# Altium schematic sheet ARM_JTAG.SchDoc(ARM_JTAG)
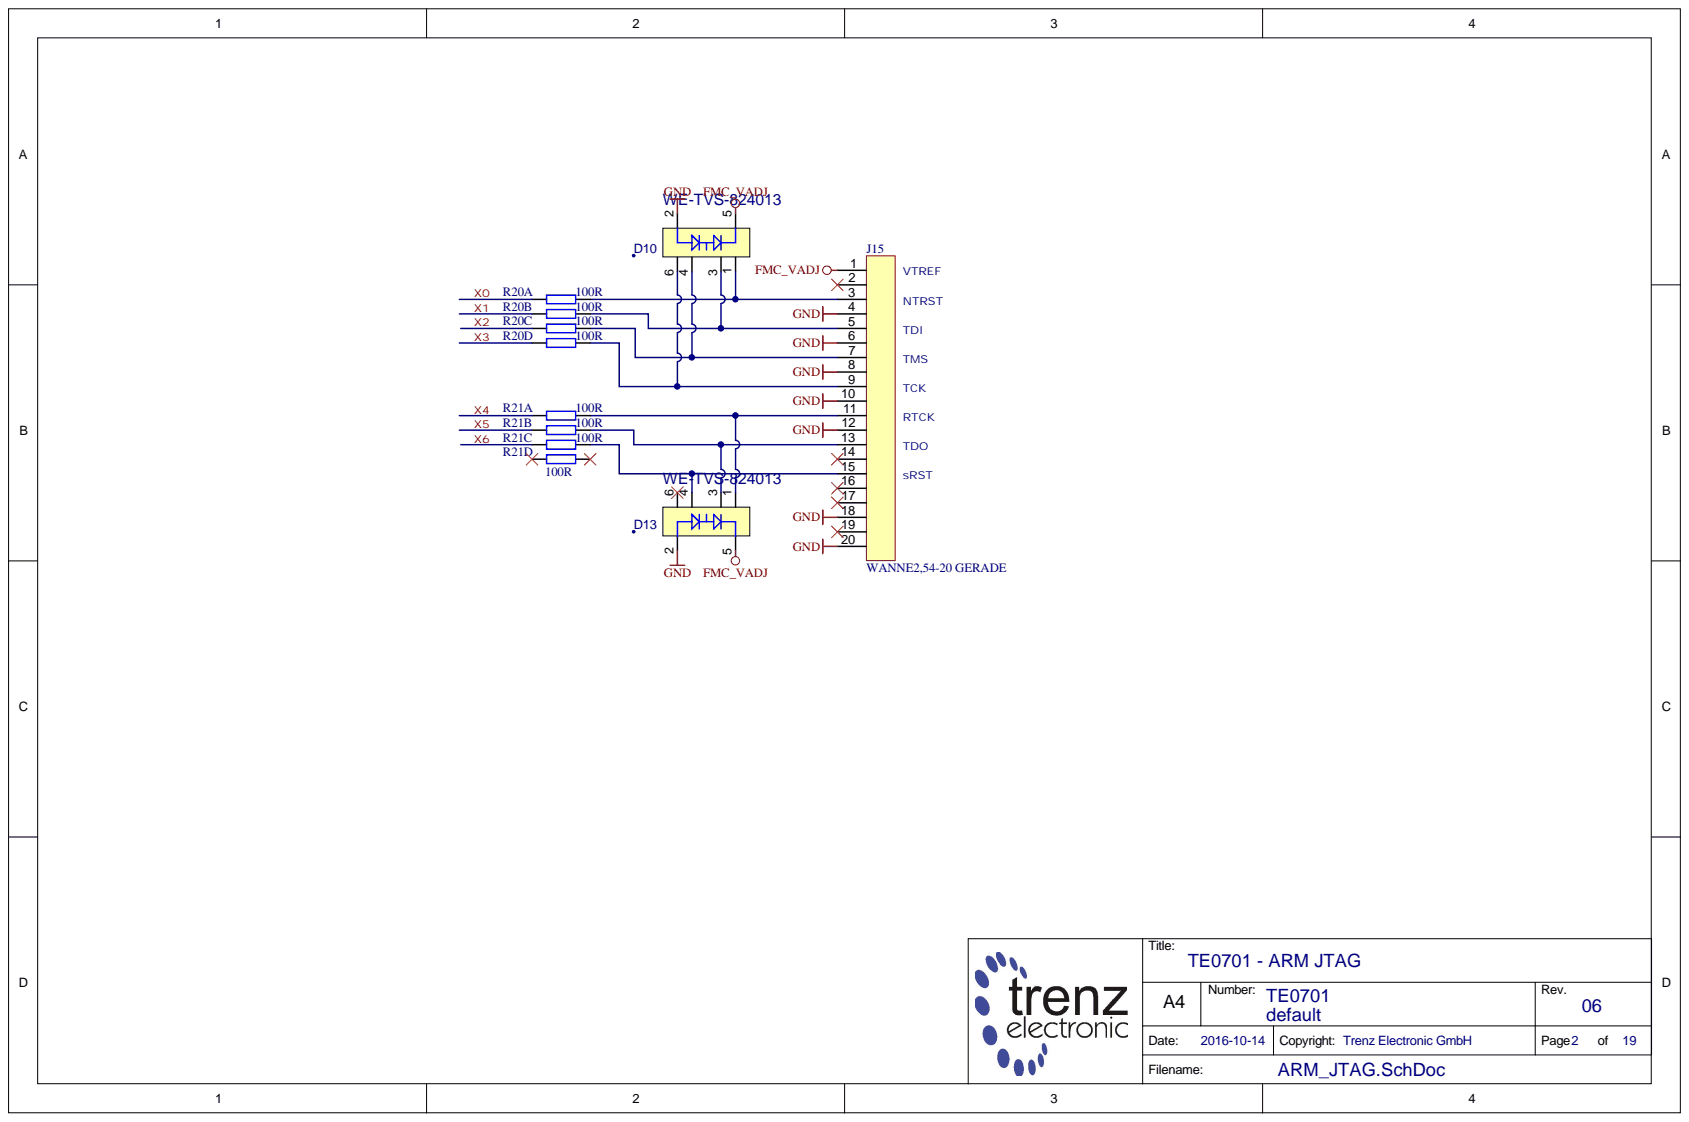
[diagram p0: ARM_JTAG.SchDoc]

## Parts
D10  WE-TVS-824013  [SOT95P280X145-6N]
D13  WE-TVS-824013  [SOT95P280X145-6N]
J15  WANNE2,54-20 GERADE  [WANNE2,54-20 GERADE]
R20  100R  [RESCAV80P160X320X70-8N]  (parts A,B,C,D)
R21  100R  [RESCAV80P160X320X70-8N]  (parts A,B,C,D)

## Nets
FMC_VADJ: D10.5 D13.5 J15.1
GND: D10.2 D13.2 J15.4 J15.6 J15.8 J15.10 J15.12 J15.18 J15.20
X0: R20.8
X1: R20.7
X2: R20.6
X3: R20.5
X4: R21.8
X5: R21.7
X6: R21.6
NetD10_1: D10.1 J15.3 R20.1
NetD10_3: D10.3 J15.5 R20.2
NetD10_4: D10.4 J15.7 R20.3
NetD10_6: D10.6 J15.9 R20.4
NetD13_1: D13.1 J15.11 R21.1
NetD13_3: D13.3 J15.13 R21.2
NetD13_4: D13.4 J15.15 R21.3

Not connected: D13 6; J15 2 14 16 17 19; R21 4 5

## Library data of the parts
D10 D13 = 23986: TVS Diode Array WE-TVS 824013; H-ART: 824013; Mixed: SMT; Preferred: Yes; AN: 23986; Case: SOT23-6; Component Kind: Standard; Database Table Name: DIODE; Library Name: TE.DbLib; Trenz-Stock: Yes; HelpURL: B:\AD\adlib\datasheet\WE-TVS-824013
J15 = 20127: Stiftleiste Wanne 20polig; Database Table Name: CON_HEADER; Mixed: THT; Trenz-Stock: Yes; AN: 20127; Component Kind: Standard; Library Name: TE.DbLib; HelpURL: B:\AD\adlib\datasheet\137
R20 R21 = 22868: WID SMD Netz 4 fach 100R; Database Table Name: R_NET; Mixed: SMT; Preferred: Yes; Tolerance: 5%; Value: 100; AN: 22868; Case: 3216 (1206); Component Kind: Standard; Library Name: TE.DbLib
